# Altium schematic sheet 06_FPGA_A.SchDoc
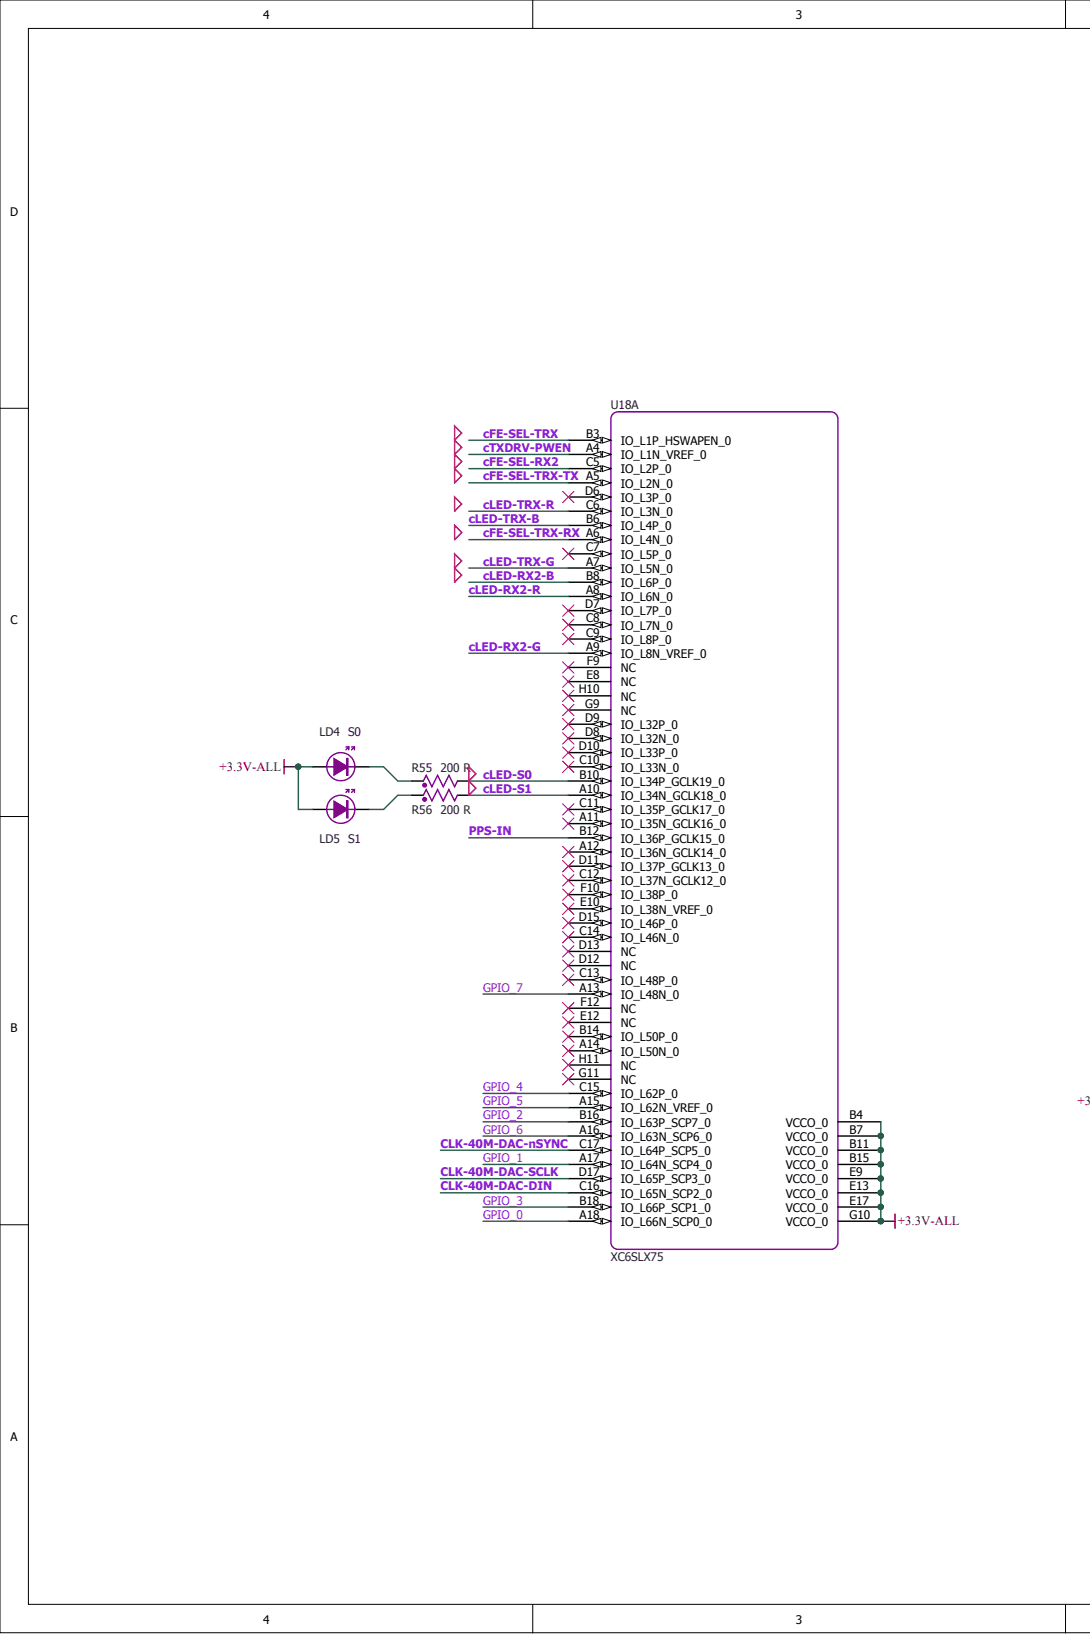
[diagram p0: 06_FPGA_A.SchDoc, left]
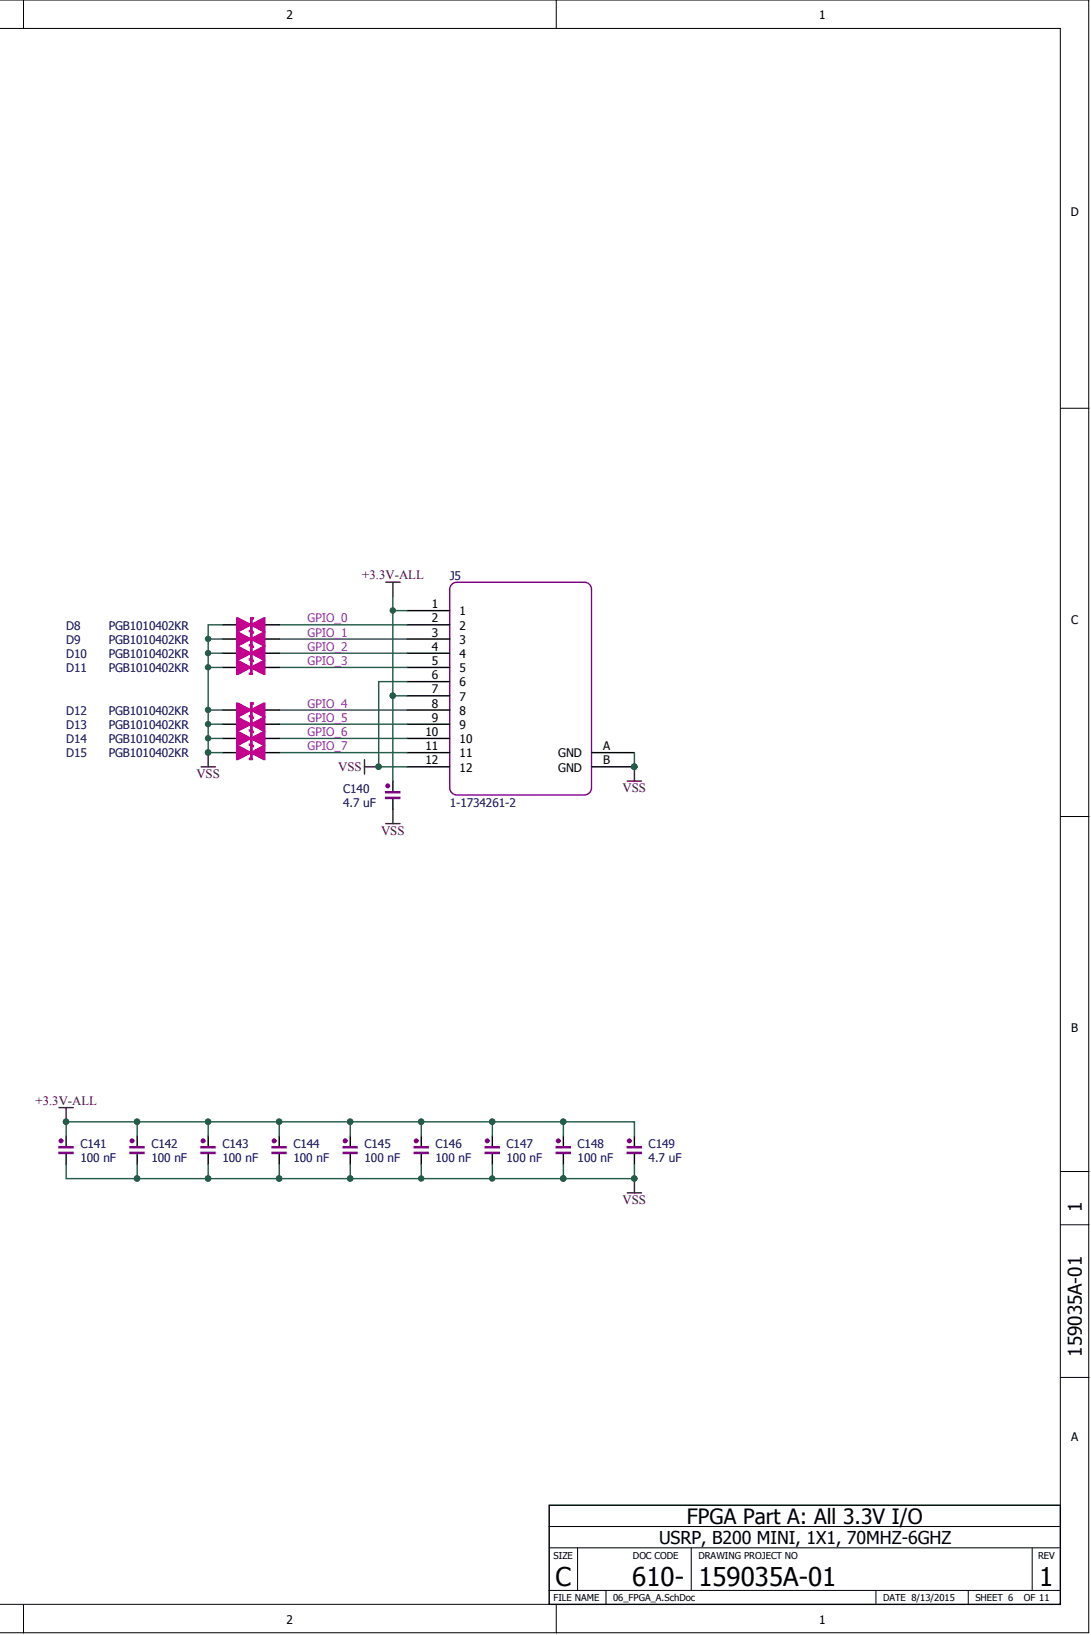
[diagram p1: 06_FPGA_A.SchDoc, right]

## Parts
C140  4.7 uF  [0402-CAP]
C141  100 nF  [0201-CAP]
C142  100 nF  [0201-CAP]
C143  100 nF  [0201-CAP]
C144  100 nF  [0201-CAP]
C145  100 nF  [0201-CAP]
C146  100 nF  [0201-CAP]
C147  100 nF  [0201-CAP]
C148  100 nF  [0201-CAP]
C149  4.7 uF  [0402-CAP]
D8  PGB1010402KR  [0402-TVS]
D9  PGB1010402KR  [0402-TVS]
D10  PGB1010402KR  [0402-TVS]
D11  PGB1010402KR  [0402-TVS]
D12  PGB1010402KR  [0402-TVS]
D13  PGB1010402KR  [0402-TVS]
D14  PGB1010402KR  [0402-TVS]
D15  PGB1010402KR  [0402-TVS]
J5  1-1734261-2  [1-1734261-2]
LD4  S0  [0603-LED]
LD5  S1  [0603-LED]
R55  200 R  [0201-RES]
R56  200 R  [0201-RES]
U18  XC6SLX75  [CS(G)484-XC6SLX75]  (part A)

## Nets
+3.3V-ALL: C140.2 C141.2 C142.2 C143.2 C144.2 C145.2 C146.2 C147.2 C148.2 C149.2 J5.1 J5.7 LD4.2 LD5.2 U18.B4(VCCO_0) U18.B7(VCCO_0) U18.B11(VCCO_0) U18.B15(VCCO_0) U18.E9(VCCO_0) U18.E13(VCCO_0) U18.E17(VCCO_0) U18.G10(VCCO_0)
VSS: C140.1 C141.1 C142.1 C143.1 C144.1 C145.1 C146.1 C147.1 C148.1 C149.1 D8.1 D9.1 D10.1 D11.1 D12.1 D13.1 D14.1 D15.1 J5.6 J5.12 J5.A(GND) J5.B(GND)
CFE-SEL-RX2: U18.C5(IO_L2P_0)
CFE-SEL-TRX: U18.B3(IO_L1P_HSWAPEN_0)
CFE-SEL-TRX-RX: U18.A6(IO_L4N_0)
CFE-SEL-TRX-TX: U18.A5(IO_L2N_0)
CLED-RX2-B: U18.B8(IO_L6P_0)
CLED-RX2-G: U18.A9(IO_L8N_VREF_0)
CLED-RX2-R: U18.A8(IO_L6N_0)
CLED-S0: R55.1 U18.B10(IO_L34P_GCLK19_0)
CLED-S1: R56.1 U18.A10(IO_L34N_GCLK18_0)
CLED-TRX-B: U18.B6(IO_L4P_0)
CLED-TRX-G: U18.A7(IO_L5N_0)
CLED-TRX-R: U18.C6(IO_L3N_0)
CLK-40M-DAC-DIN: U18.C16(IO_L65N_SCP2_0)
CLK-40M-DAC-NSYNC: U18.C17(IO_L64P_SCP5_0)
CLK-40M-DAC-SCLK: U18.D17(IO_L65P_SCP3_0)
CTXDRV-PWEN: U18.A4(IO_L1N_VREF_0)
GPIO_0: D8.2 J5.2 U18.A18(IO_L66N_SCP0_0)
GPIO_1: D9.2 J5.3 U18.A17(IO_L64N_SCP4_0)
GPIO_2: D10.2 J5.4 U18.B16(IO_L63P_SCP7_0)
GPIO_3: D11.2 J5.5 U18.B18(IO_L66P_SCP1_0)
GPIO_4: D12.2 J5.8 U18.C15(IO_L62P_0)
GPIO_5: D13.2 J5.9 U18.A15(IO_L62N_VREF_0)
GPIO_6: D14.2 J5.10 U18.A16(IO_L63N_SCP6_0)
GPIO_7: D15.2 J5.11 U18.A13(IO_L48N_0)
PPS-IN: U18.B12(IO_L36P_GCLK15_0)
NetLD4_1: LD4.1 R55.2
NetLD5_1: LD5.1 R56.2

Not connected: U18 A11(IO_L35N_GCLK16_0) A12(IO_L36N_GCLK14_0) A14(IO_L50N_0) B14(IO_L50P_0) C7(IO_L5P_0) C8(IO_L7N_0) C9(IO_L8P_0) C10(IO_L33N_0) C11(IO_L35P_GCLK17_0) C12(IO_L37N_GCLK12_0) C13(IO_L48P_0) C14(IO_L46N_0) D6(IO_L3P_0) D7(IO_L7P_0) D8(IO_L32N_0) D9(IO_L32P_0) D10(IO_L33P_0) D11(IO_L37P_GCLK13_0) D12(NC) D13(NC) D15(IO_L46P_0) E8(NC) E10(IO_L38N_VREF_0) E12(NC) F9(NC) F10(IO_L38P_0) F12(NC) G9(NC) G11(NC) H10(NC) H11(NC)

## Library data of the parts
C140 C149 = CAP: CAP, 4.7uF, X5R, 6.3V, ±20%, 0402, PbF; PartNumber: GRM155R60J475ME47; Designer: shyul; PCBMarker: Capacitor; PinCountHole: 0; PinCountSMD: 2; Vendor: muRata; Component Kind: Standard; SerialNumber: 0; Value: 4.7 uF; Version: 1.0; VersionDate: 04-27-2011; Library Name: Basc_1_Passive.IntLib; Publisher: Cowin
C141-C148 = CAP: CAP,SM,0.1UF,16V,10%,CER,X5R,0201,H=.014IN; PartNumber: GRM033R61C104KE14D; Designer: shyul; PCBMarker: Capacitor; PinCountHole: 0; PinCountSMD: 2; SerialNumber: 717062-01; Vendor: MURATA; Component Kind: Standard; Value: 100 nF; Version: 1.0; VersionDate: 04-27-2011; Library Name: Basc_1_Passive.IntLib; Publisher: Cowin
D8-D15 = PGB10101402KR: ESD SUPPRESSOR, SM, 12VDC WORKING, 250V CLAMPING, 0402, H=.015IN; Designer: shyul; PCBMarker: Diodes; PinCountHole: 0; PinCountSMD: 3; SerialNumber: 730830-01; Vendor: Littelfuse; Component Kind: Standard; PartNumber: PGB1010402KR; Value: PGB1010402KR; Version: 1.0; VersionDate: 09-09-2014; Library Name: Basc_3_Diode_Transistor.IntLib; Publisher: Cowin
J5 = ARGON_GPIO: GPIO Connector; Designer: shyul; PCBMarker: JTAG; PinCountHole: 0; PinCountSMD: 14; Vendor: Tyco; Component Kind: Standard; Library Name: Argon.SchLib; PartNumber: 1-1734261-2; SerialNumber: 0; Value: 1-1734261-2; Version: 1.0; VersionDate: 11-07-2014; Publisher: Shyu Lee
LD4 LD5 = LED: LED, Green, 0603; PartNumber: TLMG1100-GS08; Designer: shyul; PinCountHole: 0; PinCountSMD: 2; Vendor: Vishay; Component Kind: Standard; PCBMarker: LED; SerialNumber: 0; Value: GREEN; Version: 1.0; VersionDate: 04-27-2011; Library Name: Basc_4_Other.IntLib; Publisher: Cowin
  LD4: Status: S0
  LD5: Status: S1
R55 R56 = RES: RES, 200R, ±1%, 1/20W, 0201, PbF; PartNumber: RK73H1HTTC2000F; Designer: shyul; PCBMarker: Resistor; PinCountHole: 0; PinCountSMD: 2; Vendor: KOA; Component Kind: Standard; SerialNumber: 0; Value: 200 R; Version: 1.0; VersionDate: 04-27-2011; Library Name: Basc_1_Passive.IntLib; Publisher: Cowin
U18 = XC6SLX75: Xilinx Spartan-6 FPGA; Designer: shyul; PinCountSMD: 484; SerialNumber: 0; Component Kind: Standard; PartNumber: XC6SLX75; Value: XC6SLX75; Vendor: Xilinx; Version: 1.0; VersionDate: 09-18-2014; Library Name: Argon.SchLib; Publisher: Shyu Lee
